# Altium schematic sheet USB.SchDoc
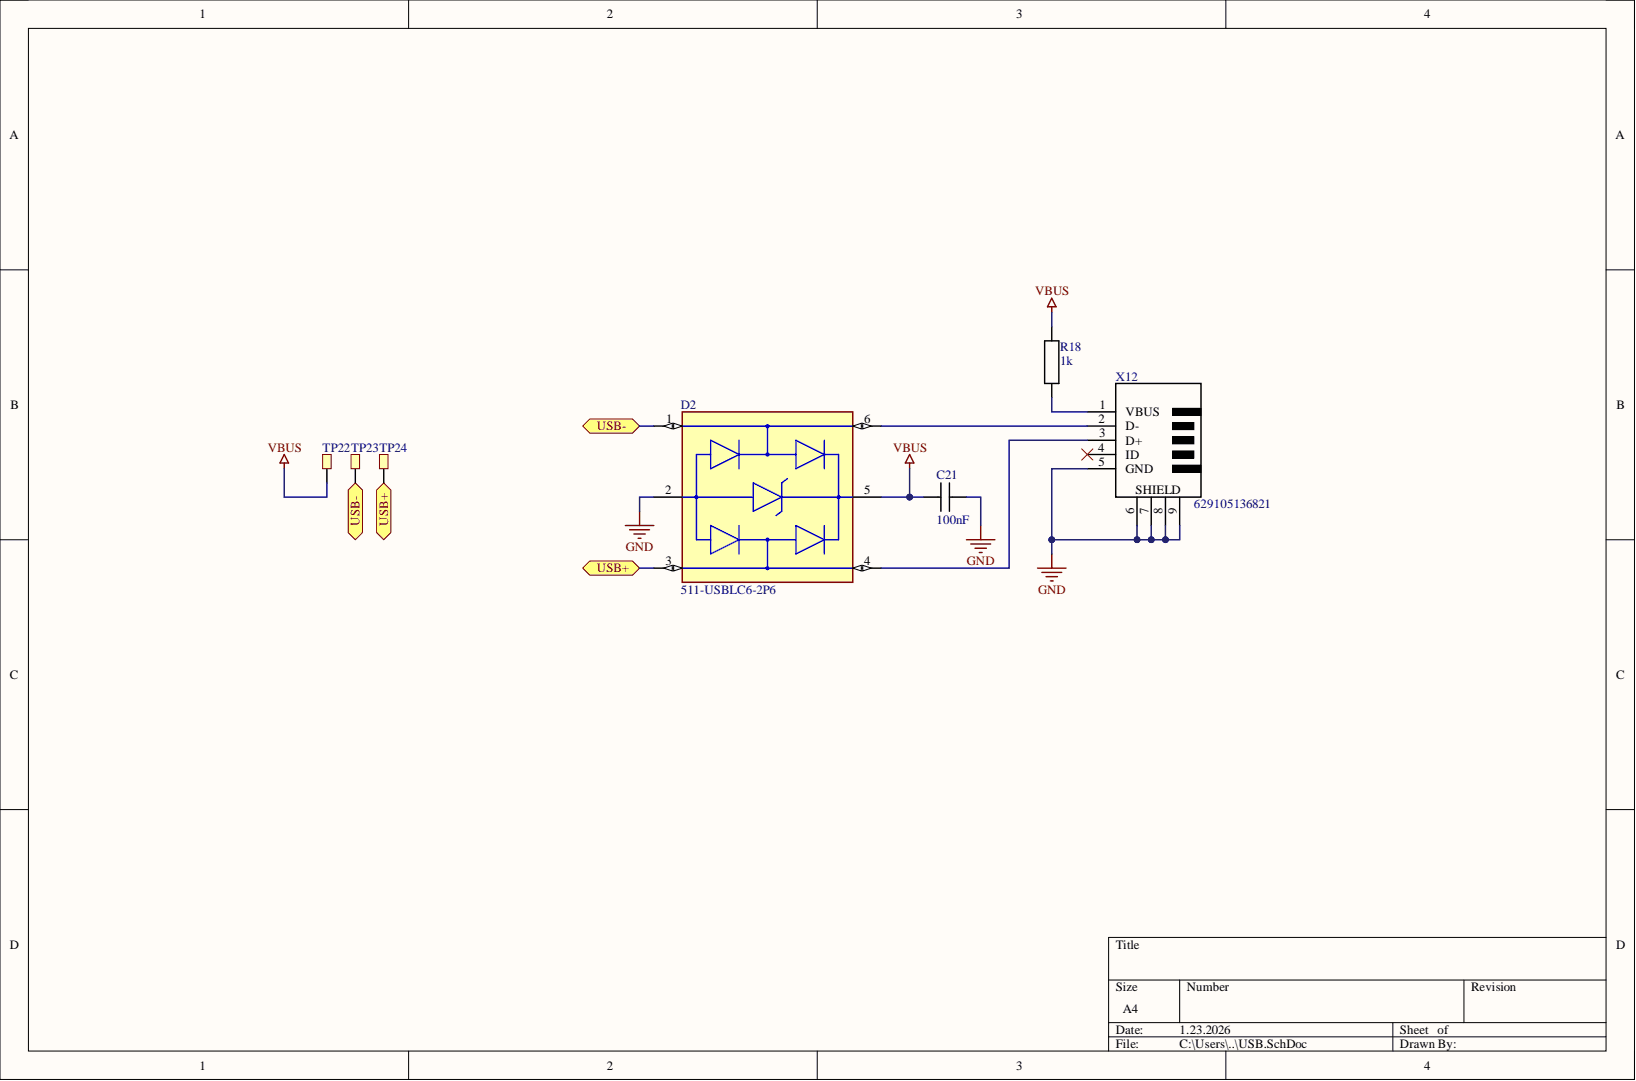
[diagram p0: USB.SchDoc]

## Parts
C21  100nF  [CAPC1608X08M]
D2  USBLC6-2P6  Supplier Part Number 1: 511-USBLC6-2P6  [FP-SOT-666-MFG]
R18  1k  [RESC1608X06M]
TP22  5019  [TESTPOINT_SMD]  (value not drawn)
TP23  5019  [TESTPOINT_SMD]  (value not drawn)
TP24  5019  [TESTPOINT_SMD]  (value not drawn)
X12  629105136821  [WE_629105136821]

## Ports
USB+, USB-

## Nets
GND: C21.2 D2.2 X12.5(GND) X12.6 X12.7 X12.8 X12.9
VBUS: C21.1 D2.5 R18.2 TP22.1
NetD2_1: D2.1 TP23.1
NetD2_3: D2.3 TP24.1
NetD2_4: D2.4 X12.3(D+)
NetD2_6: D2.6 X12.2(D-)
NetR18_1: R18.1 X12.1(VBUS)

Not connected: X12 4(ID)

## Library data of the parts
C21 = CMP-065-000000-2: CAPC 100nF X7R 50V 10% 1608 (0603 INCH); Manufacturer: TDK; Manufacturer Number: CGA3E2X7R1H104K080AA; Current: NULL; IsStocked: 1; PN: PN-338083; TemperatureRange: -55°C to +125°C; Category: Passives; Component Kind: Standard; Dielectric: X7R; Library Name: ETML-ES; Power: NULL; SubCategory: Condensateurs ceramique; Supplier 1: ETML; Supplier Part Number 1: FAB.0603_C 100n; Supplier Price 1: 0.0100; TemperatureCoeff: NULL; Tolerance: ±10%; Value: 100nF; Voltage: 50V
R18 = CMP-066-000112-2: RES 1.0kohm  ±1% 0.1W  1608 (0603 INCH); Manufacturer: Yageo; Manufacturer Number: RC0603FR-071KL; IsStocked: 1; PN: PN-368287; TemperatureCoeff: ±100ppm/°C; TemperatureRange: -55°C to 155°C; Voltage: 75V; Category: Passives; Component Kind: Standard; Library Name: ETML-ES; Power: 0.1W; SubCategory: Resistances couche epaisse; Supplier 1: ETML; Supplier Part Number 1: FAB.0603_R 1k; Supplier Price 1: 0.0100; Tolerance: ±1%; Value: 1k
TP22-TP24 = TESTPOINT: Test point smd; Component Kind: Standard; Supplier 1: Mouser; Supplier Part Number 1: 534-5019; Library Name: 2008_SablierElectronique_SCHLIB.SchLib
X12 = CMP-054-000011-1: USB MICRO 5P Horizontal SMT; Manufacturer: Würth Electronik; IsStocked: True; PN: PN-869488; TemperatureRange: -40°C to +85°C; Category: Connectors; Component Kind: Standard; Library Name: ETML-ES; Manufacturer Number: 629105136821; SubCategory: USB; Supplier 1: ETML; Supplier Part Number 1: MAG.246.110; Supplier Price 1: 1.48; Value: 629105136821
D2 = USBLC6-2P6: Component Kind: Standard; Supplier 1: Mouser; Library Name: 2008_SablierElectronique_SCHLIB.SchLib
